# Altium schematic sheet 32电路图.SchDoc
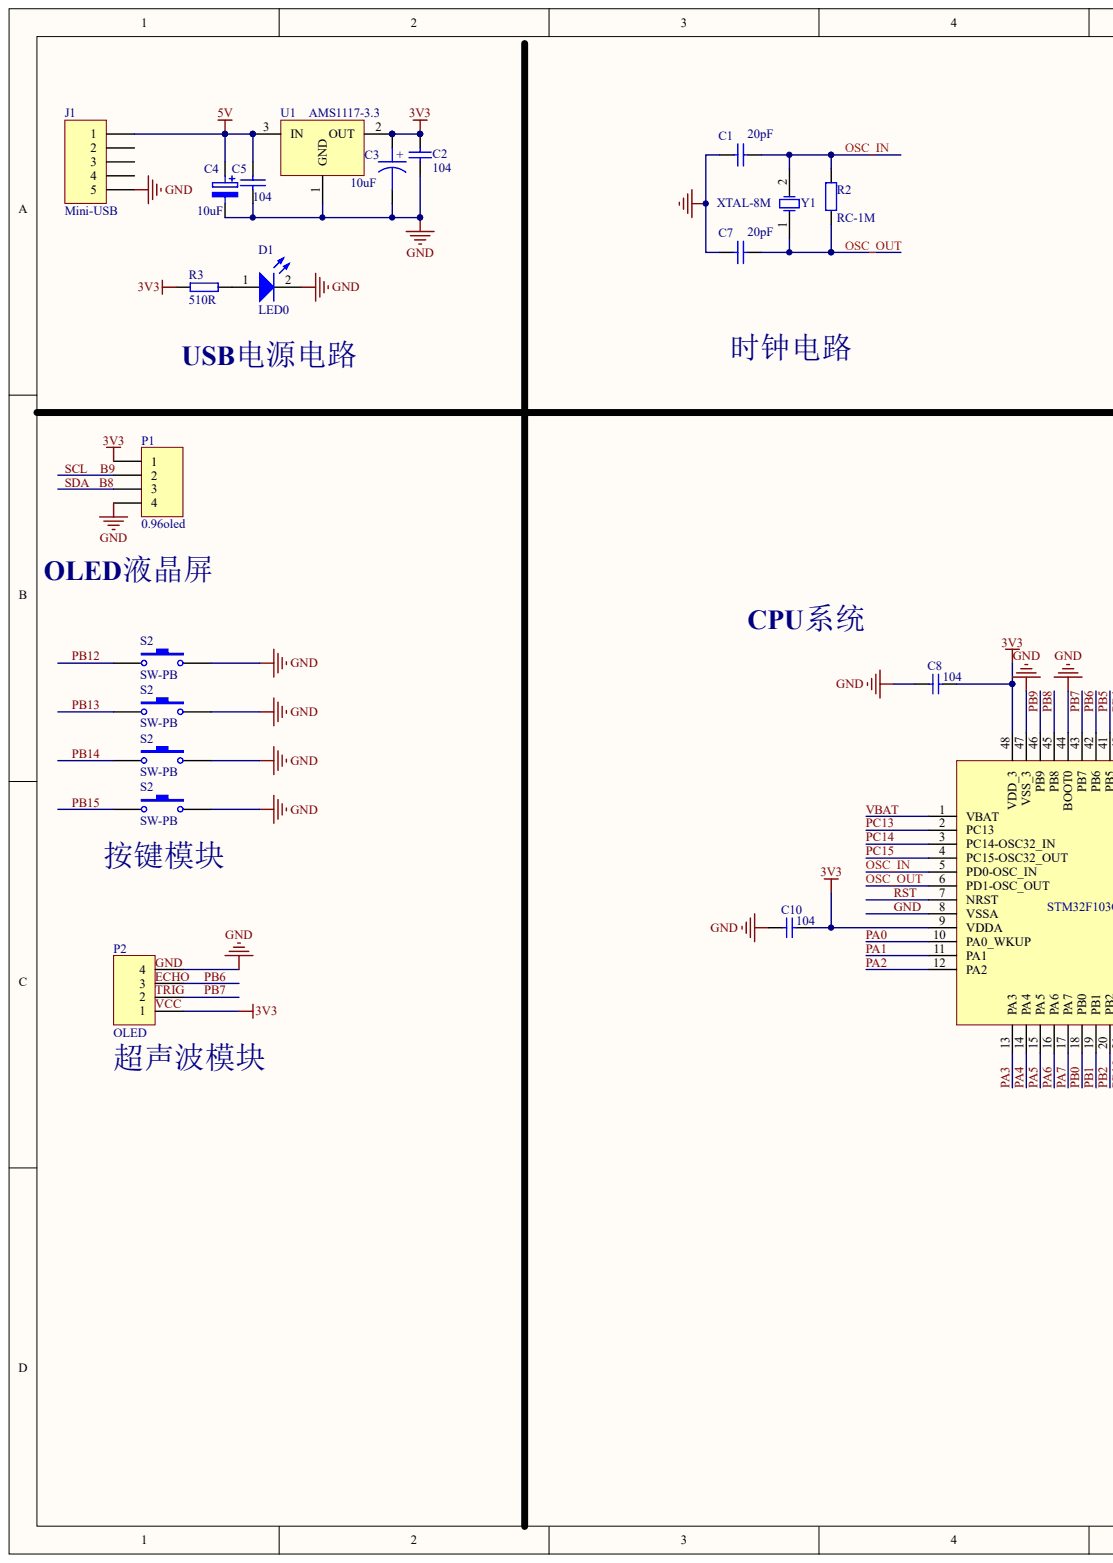
[diagram p0: 32电路图.SchDoc, left]
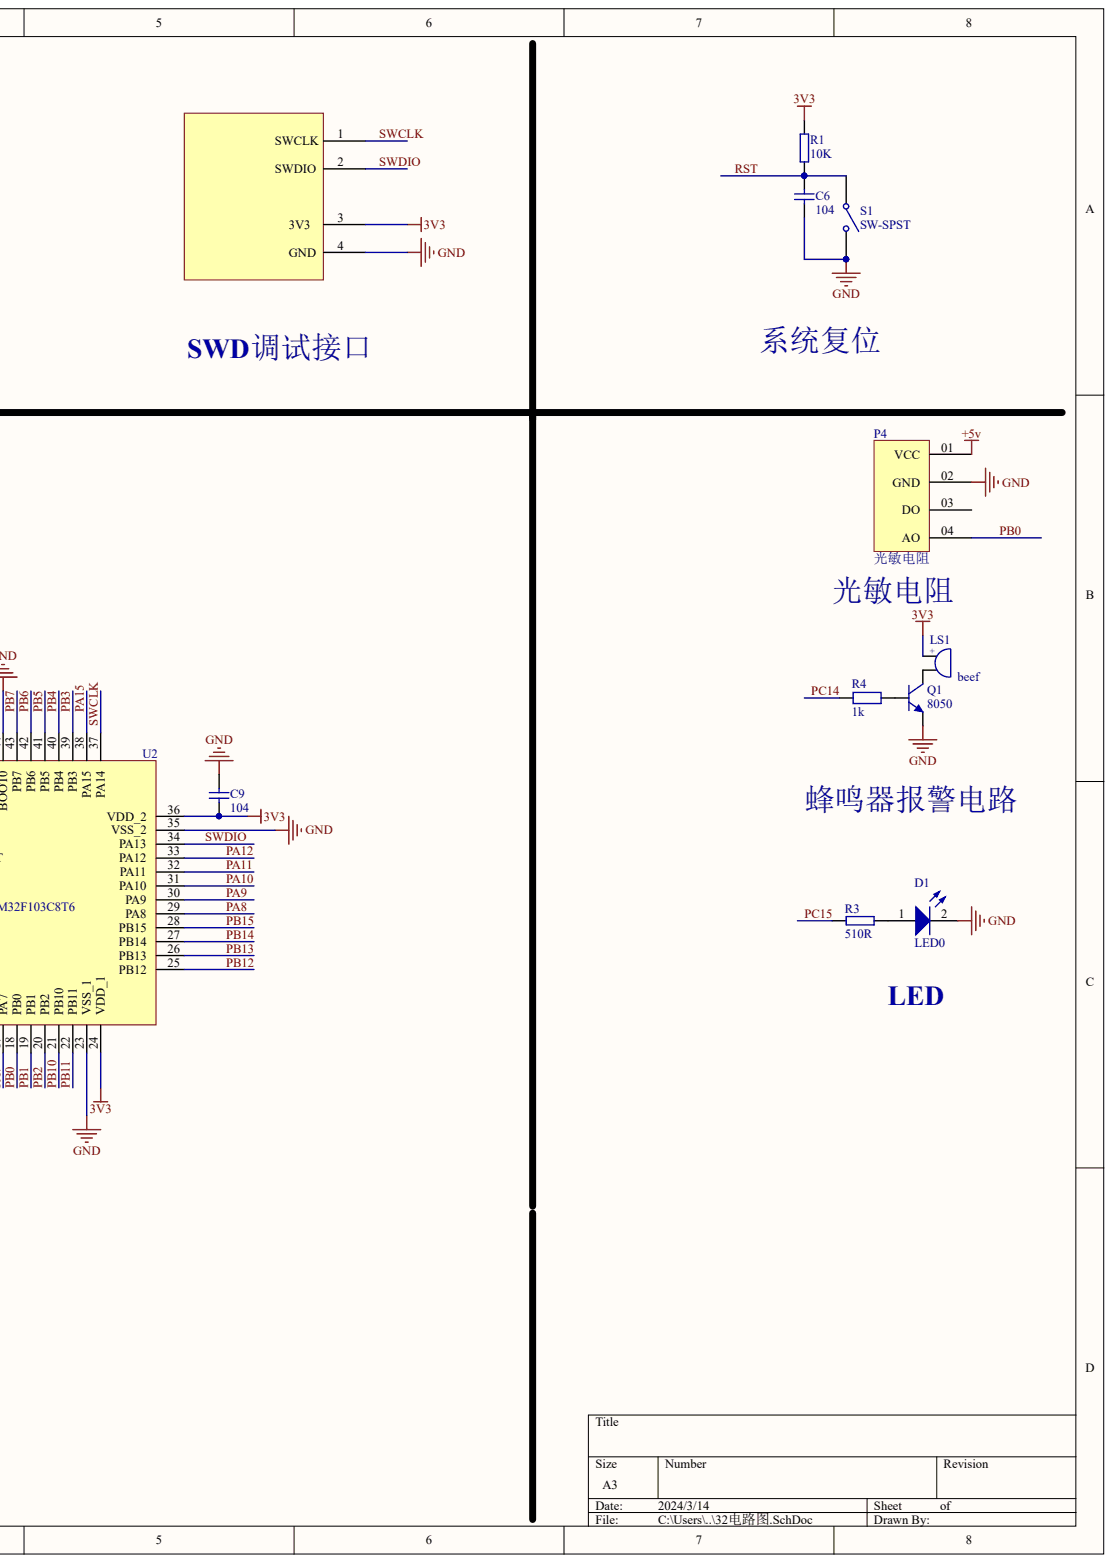
[diagram p1: 32电路图.SchDoc, right]

## Parts
C1  value 20pF  (hidden comment: S0805-20P)
C2  104
C3  10uF
C4  10uF
C5  104
C6  104
C7  value 20pF  (hidden comment: S0805-20P)
C8  104
C9  104
C10  104
D1  LED0
D1  LED0
J1  Mini-USB
LS1  beef
P1  0.96oled
P2  OLED
P4  光敏电阻
Q1  8050
R1  10K
R2  RC-1M
R3  510R
R3  510R
R4  1k
S1  SW-SPST
S2  SW-PB
S2  SW-PB
S2  SW-PB
S2  SW-PB
U1  AMS1117-3.3
U2  STM32F103C8T6
Y1  XTAL-8M

## Nets
3V3: C2.1 C3.1 C8.1 C9.1 C10.1 LS1.1 P1.1 P2.1 R1.2 R3.2 U1.2 U2.9(VDDA) U2.24(VDD_1) U2.36(VDD_2) U2.48(VDD_3)
5V: C4.1 C5.1 J1.1 U1.3
+5V: P4.01(VCC)
GND: C1.1 C2.2 C3.2 C4.2 C5.2 C6.2 C7.1 C8.2 C9.2 C10.2 D1.2 J1.5 P1.4 P2.4 P4.02(GND) Q1.1 S1.2 S2.2 U1.1 U2.8(VSSA) U2.23(VSS_1) U2.35(VSS_2) U2.44(BOOT0) U2.47(VSS_3)
OSC_IN: C1.2 R2.2 U2.5(PD0-OSC_IN) Y1.2
OSC_OUT: C7.2 R2.1 U2.6(PD1-OSC_OUT) Y1.1
PA0: U2.10(PA0_WKUP)
PA1: U2.11(PA1)
PA2: U2.12(PA2)
PA3: U2.13(PA3)
PA4: U2.14(PA4)
PA5: U2.15(PA5)
PA6: U2.16(PA6)
PA7: U2.17(PA7)
PA8: U2.29(PA8)
PA9: U2.30(PA9)
PA10: U2.31(PA10)
PA11: U2.32(PA11)
PA12: U2.33(PA12)
PA15: U2.38(PA15)
PB0: P4.04(AO) U2.18(PB0)
PB1: U2.19(PB1)
PB2: U2.20(PB2)
PB3: U2.39(PB3)
PB4: U2.40(PB4)
PB5: U2.41(PB5)
PB6: P2.3 U2.42(PB6)
PB7: P2.2 U2.43(PB7)
PB8: U2.45(PB8)
PB9: U2.46(PB9)
PB10: U2.21(PB10)
PB11: U2.22(PB11)
PB12: S2.1 U2.25(PB12)
PB13: S2.1 U2.26(PB13)
PB14: S2.1 U2.27(PB14)
PB15: S2.1 U2.28(PB15)
PC13: R4.1 U2.2(PC13)
PC14: U2.3(PC14-OSC32_IN)
PC15: R3.2 U2.4(PC15-OSC32_OUT)
RST: C6.1 R1.1 S1.1 U2.7(NRST)
SCL    B9: P1.2
SDA   B8: P1.3
SWCLK: U2.37(PA14)
SWDIO: U2.34(PA13)
VBAT: U2.1(VBAT)
NetD1_1: D1.1 R3.1
NetD1_1: D1.1 R3.1
NetLS1_2: LS1.2 Q1.3
NetQ1_2: Q1.2 R4.2
ECHO:
TRIG:
VCC:

Not connected: J1 2 3 4; P4 03(DO)

## Library data of the parts
C6 = (no symbol name): Tolerate: 50V; Value: 100nF; TOL: 10%; ERP: 0103020075; PCB_Layout: Top Layer SMC; Revision: 2012-3-28; Publisher: ZL_A0OFFICE
C8-C10 = (no symbol name): Tolerate: 50V; Value: 20pF; TOL: 2%; ERP: 0103020090; PCB_Layout: Top Layer SMC; Revision: 2012-3-28; Publisher: ZL_A0OFFICE
LS1 = (no symbol name): BOM名称: 蜂鸣器; 版权归属: 封装库来自淘宝 ID：黄晶宝石; 联系售后: https://shop463601444.taobao.com
Q1 = (no symbol name): BOM名称: 高频放大-NPN型; 版权归属: 封装库来自淘宝 ID：黄晶宝石; 属性: 40V 1.5A 1W 100MHZ; 学习资源: https://shop463601444.taobao.com
R4 = (no symbol name): BOM名称: 贴片电阻; 版权归属: 来自淘宝 ID：黄晶宝石; 联系售后: https://shop463601444.taobao.com
C1-C5 C7 D1 D1 J1 P1 P2 P4 R1-R3 R3 S1 S2 S2 S2 S2 U1 U2 Y1 = (no symbol name)
  C1 C7: Published: 8-Jun-2000; LatestRevisionDate: 17-Jul-2002; LatestRevisionNote: Re-released for DXP Platform.
  C2: Published: 8-Jun-2000; LatestRevisionDate: 17-Jul-2002; LatestRevisionNote: Re-released for DXP Platform.; PackageReference: RAD-0.3
  P1 P2: LatestRevisionDate: 17-Jul-2002; LatestRevisionNote: Re-released for DXP Platform.
  R2: Published: 8-Jun-2000; LatestRevisionDate: 17-Jul-2002; LatestRevisionNote: Re-released for DXP Platform.; Value: RC-1M
  S2 S2 S2 S2: Published: 24-Mar-1999; LatestRevisionDate: 17-Jul-2002; LatestRevisionNote: Re-released for DXP Platform.; PackageReference: SPST-2; PackageDescription: Switch; 2 Leads
  Y1: Published: *; LatestRevisionDate: *; LatestRevisionNote: *; Publisher: *
  C1 C2 C7 P1 P2 R2 S2 S2 S2 S2: Publisher: Altium Limited
